# Altium schematic sheet FPGA.SchDoc(FPGA)
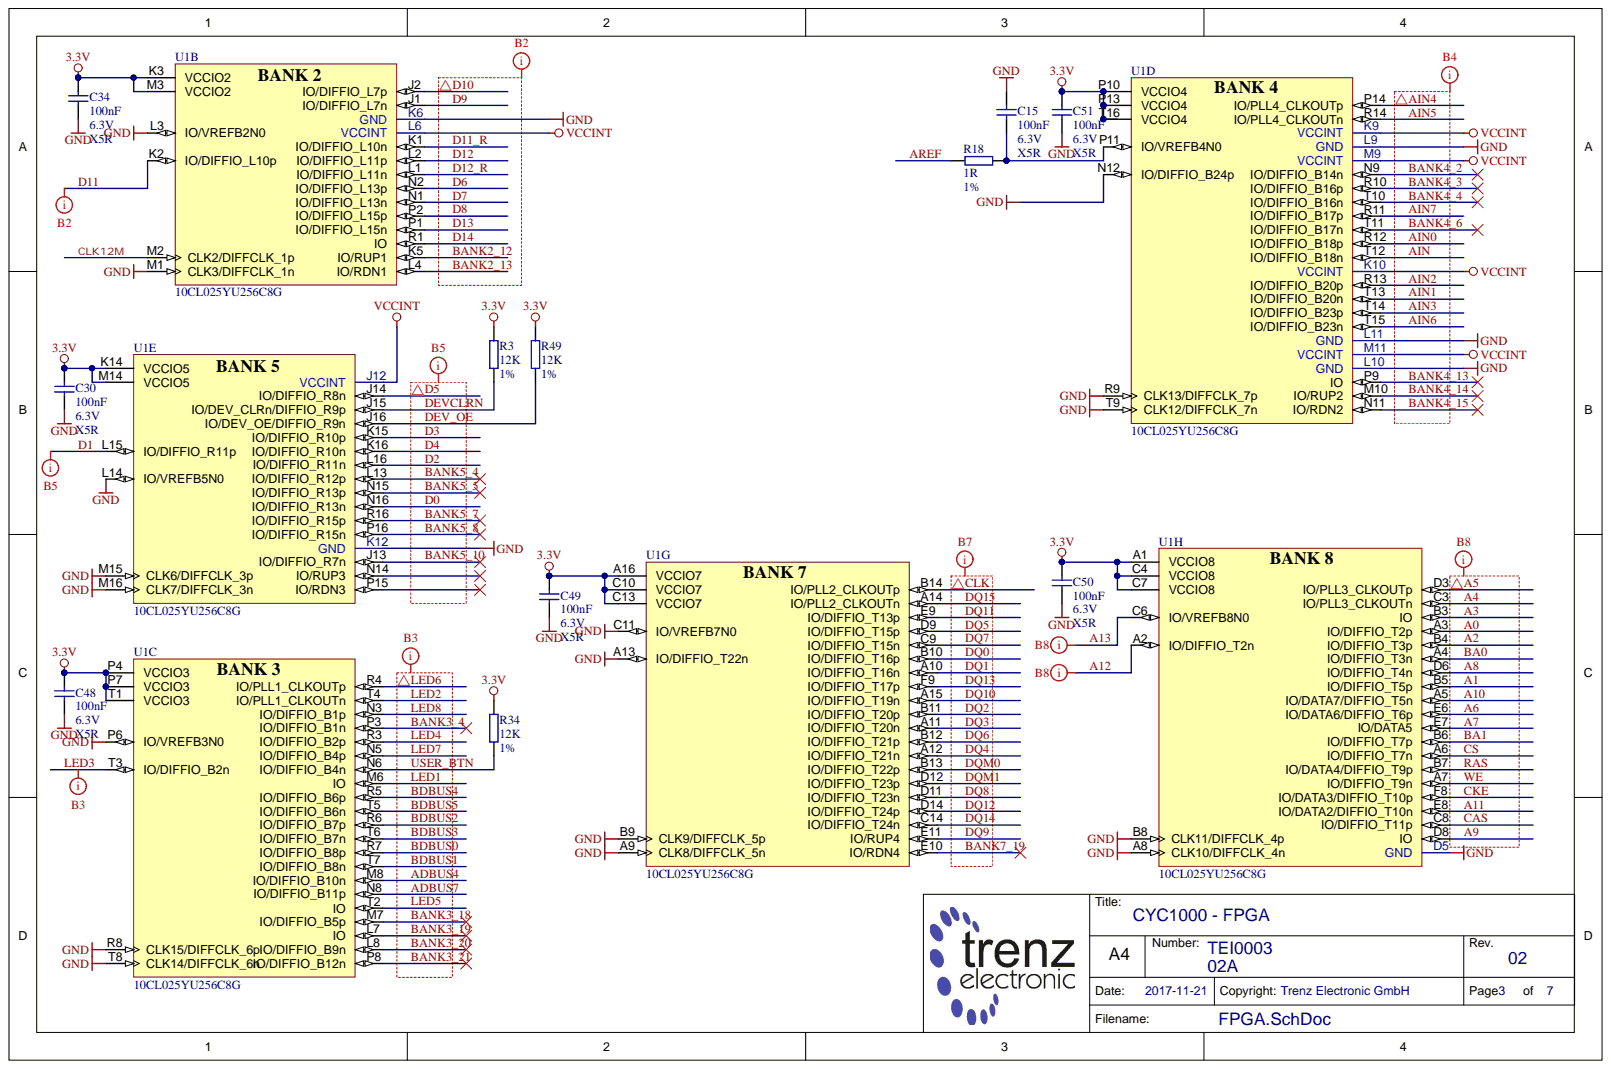
[diagram p0: FPGA.SchDoc]

## Parts
C15  100nF  Voltage: 6.3V  [CAPC0603X33N]
C30  100nF  Voltage: 6.3V  [CAPC0603X33N]
C34  100nF  Technology: X5R  Voltage: 6.3V  [CAPC0603X33N]
C48  100nF  Voltage: 6.3V  [CAPC0603X33N]
C49  100nF  Technology: X5R  Voltage: 6.3V  [CAPC0603X33N]
C50  100nF  Technology: X5R  Voltage: 6.3V  [CAPC0603X33N]
C51  100nF  Voltage: 6.3V  [CAPC0603X33N]
R3  12K  Tolerance: 1%  [RESC1005X40N]
R18  1R  Tolerance: 1%  [RESC1005X40N]
R34  12K  Tolerance: 1%  [RESC1005X40N]
R49  12K  Tolerance: 1%  [RESC1005X40N]
U1  10CL025YU256C8G  [BGA256C80_1400X1400X150B50]  (parts B,C,D,E,G,H)

## Nets
3.3V: C30.1 C34.1 C48.1 C49.1 C50.1 C51.1 R3.2 R34.2 R49.2 U1.A1(VCCIO8) U1.A16(VCCIO7) U1.C4(VCCIO8) U1.C7(VCCIO8) U1.C10(VCCIO7) U1.C13(VCCIO7) U1.K3(VCCIO2) U1.K14(VCCIO5) U1.M3(VCCIO2) U1.M14(VCCIO5) U1.P4(VCCIO3) U1.P7(VCCIO3) U1.P10(VCCIO4) U1.P13(VCCIO4) U1.T1(VCCIO3) U1.T16(VCCIO4)
GND: C15.2 C30.2 C34.2 C48.2 C49.2 C50.2 C51.2 U1.A8(CLK10/DIFFCLK_4n) U1.A9(CLK8/DIFFCLK_5n) U1.A13(IO/DIFFIO_T22n) U1.B8(CLK11/DIFFCLK_4p) U1.B9(CLK9/DIFFCLK_5p) U1.C11(IO/VREFB7N0) U1.D5(GND) U1.K6(GND) U1.K12(GND) U1.L3(IO/VREFB2N0) U1.L9(GND) U1.L10(GND) U1.L11(GND) U1.L14(IO/VREFB5N0) U1.M1(CLK3/DIFFCLK_1n) U1.M15(CLK6/DIFFCLK_3p) U1.M16(CLK7/DIFFCLK_3n) U1.N12(IO/DIFFIO_B24p) U1.P6(IO/VREFB3N0) U1.R8(CLK15/DIFFCLK_6p) U1.R9(CLK13/DIFFCLK_7p) U1.T8(CLK14/DIFFCLK_6n) U1.T9(CLK12/DIFFCLK_7n)
VCCINT: U1.J12(VCCINT) U1.K9(VCCINT) U1.K10(VCCINT) U1.L6(VCCINT) U1.M9(VCCINT) U1.M11(VCCINT)
A0: U1.A3(IO/DIFFIO_T2p)
A1: U1.B5(IO/DIFFIO_T5p)
A2: U1.B4(IO/DIFFIO_T3p)
A3: U1.B3(IO)
A4: U1.C3(IO/PLL3_CLKOUTn)
A5: U1.D3(IO/PLL3_CLKOUTp)
A6: U1.E6(IO/DATA6/DIFFIO_T6p)
A7: U1.E7(IO/DATA5)
A8: U1.D6(IO/DIFFIO_T4n)
A9: U1.D8(IO)
A10: U1.A5(IO/DATA7/DIFFIO_T5n)
A11: U1.E8(IO/DATA2/DIFFIO_T10n)
A12: U1.A2(IO/DIFFIO_T2n)
A13: U1.C6(IO/VREFB8N0)
ADBUS4: U1.M8(IO/DIFFIO_B10n)
ADBUS7: U1.N8(IO/DIFFIO_B11p)
AIN: U1.T12(IO/DIFFIO_B18n)
AIN0: U1.R12(IO/DIFFIO_B18p)
AIN1: U1.T13(IO/DIFFIO_B20n)
AIN2: U1.R13(IO/DIFFIO_B20p)
AIN3: U1.T14(IO/DIFFIO_B23p)
AIN4: U1.P14(IO/PLL4_CLKOUTp)
AIN5: U1.R14(IO/PLL4_CLKOUTn)
AIN6: U1.T15(IO/DIFFIO_B23n)
AIN7: U1.R11(IO/DIFFIO_B17p)
AREF: R18.1
BA0: U1.A4(IO/DIFFIO_T3n)
BA1: U1.B6(IO/DIFFIO_T7p)
BANK2_12: U1.K5(IO/RUP1)
BANK2_13: U1.L4(IO/RDN1)
BANK3_4: U1.P3(IO/DIFFIO_B1n)
BANK3_18: U1.M7(IO/DIFFIO_B5p)
BANK3_19: U1.L7(IO)
BANK3_20: U1.L8(IO/DIFFIO_B9n)
BANK3_21: U1.P8(IO/DIFFIO_B12n)
BANK4_2: U1.N9(IO/DIFFIO_B14n)
BANK4_3: U1.R10(IO/DIFFIO_B16p)
BANK4_4: U1.T10(IO/DIFFIO_B16n)
BANK4_6: U1.T11(IO/DIFFIO_B17n)
BANK4_13: U1.P9(IO)
BANK4_14: U1.M10(IO/RUP2)
BANK4_15: U1.N11(IO/RDN2)
BANK5_4: U1.L13(IO/DIFFIO_R12p)
BANK5_5: U1.N15(IO/DIFFIO_R13p)
BANK5_7: U1.R16(IO/DIFFIO_R15p)
BANK5_8: U1.P16(IO/DIFFIO_R15n)
BANK5_10: U1.J13(IO/DIFFIO_R7n)
BANK7_19: U1.E10(IO/RDN4)
BDBUS0: U1.R7(IO/DIFFIO_B8p)
BDBUS1: U1.T7(IO/DIFFIO_B8n)
BDBUS2: U1.R6(IO/DIFFIO_B7p)
BDBUS3: U1.T6(IO/DIFFIO_B7n)
BDBUS4: U1.R5(IO/DIFFIO_B6p)
BDBUS5: U1.T5(IO/DIFFIO_B6n)
CAS: U1.C8(IO/DIFFIO_T11p)
CKE: U1.F8(IO/DATA3/DIFFIO_T10p)
CLK: U1.B14(IO/PLL2_CLKOUTp)
CLK12M: U1.M2(CLK2/DIFFCLK_1p)
CS: U1.A6(IO/DIFFIO_T7n)
D0: U1.N16(IO/DIFFIO_R13n)
D1: U1.L15(IO/DIFFIO_R11p)
D2: U1.L16(IO/DIFFIO_R11n)
D3: U1.K15(IO/DIFFIO_R10p)
D4: U1.K16(IO/DIFFIO_R10n)
D5: U1.J14(IO/DIFFIO_R8n)
D6: U1.N2(IO/DIFFIO_L13p)
D7: U1.N1(IO/DIFFIO_L13n)
D8: U1.P2(IO/DIFFIO_L15p)
D9: U1.J1(IO/DIFFIO_L7n)
D10: U1.J2(IO/DIFFIO_L7p)
D11: U1.K2(IO/DIFFIO_L10p)
D11_R: U1.K1(IO/DIFFIO_L10n)
D12: U1.L2(IO/DIFFIO_L11p)
D12_R: U1.L1(IO/DIFFIO_L11n)
D13: U1.P1(IO/DIFFIO_L15n)
D14: U1.R1(IO)
DEV_OE: R49.1 U1.J16(IO/DEV_OE/DIFFIO_R9n)
DEVCLRN: R3.1 U1.J15(IO/DEV_CLRn/DIFFIO_R9p)
DQ0: U1.B10(IO/DIFFIO_T16p)
DQ1: U1.A10(IO/DIFFIO_T16n)
DQ2: U1.B11(IO/DIFFIO_T20p)
DQ3: U1.A11(IO/DIFFIO_T20n)
DQ4: U1.A12(IO/DIFFIO_T21n)
DQ5: U1.D9(IO/DIFFIO_T15p)
DQ6: U1.B12(IO/DIFFIO_T21p)
DQ7: U1.C9(IO/DIFFIO_T15n)
DQ8: U1.D11(IO/DIFFIO_T23n)
DQ9: U1.E11(IO/RUP4)
DQ10: U1.A15(IO/DIFFIO_T19n)
DQ11: U1.E9(IO/DIFFIO_T13p)
DQ12: U1.D14(IO/DIFFIO_T24p)
DQ13: U1.F9(IO/DIFFIO_T17p)
DQ14: U1.C14(IO/DIFFIO_T24n)
DQ15: U1.A14(IO/PLL2_CLKOUTn)
DQM0: U1.B13(IO/DIFFIO_T22p)
DQM1: U1.D12(IO/DIFFIO_T23p)
LED1: U1.M6(IO)
LED2: U1.T4(IO/PLL1_CLKOUTn)
LED3: U1.T3(IO/DIFFIO_B2n)
LED4: U1.R3(IO/DIFFIO_B2p)
LED5: U1.T2(IO)
LED6: U1.R4(IO/PLL1_CLKOUTp)
LED7: U1.N5(IO/DIFFIO_B4p)
LED8: U1.N3(IO/DIFFIO_B1p)
RAS: U1.B7(IO/DATA4/DIFFIO_T9p)
USER_BTN: R34.1 U1.N6(IO/DIFFIO_B4n)
WE: U1.A7(IO/DIFFIO_T9n)
NetC15_1: C15.1 R18.2 U1.P11(IO/VREFB4N0)
N00177:
N00178:
N00179:
N00180:
N00181:
N00182:

Not connected: U1 N14(IO/RUP3) P15(IO/RDN3)

## Library data of the parts
C15 C30 C34 C48-C51 = 24915: KON 100nF 6.3V X5R SMD 10%; Database Table Name: C_0603_0201; Mixed: SMT; Preferred: Yes; Value: 100n; AN: 24915; Case: 0603 (0201); Component Kind: Standard; Library Name: TE.DbLib; Tolerance: 10%
  C15 C30 C48 C51: Technology: X5R
R3 R34 R49 = 22598: WID SMD 12K 1% 0,063W; Database Table Name: R_1005_0402; Mixed: SMT; Preferred: Yes; AN: 22598; Case: 1005 (0402); Component Kind: Standard; Library Name: TE.DbLib; Technology: TK100; Value: 12K
R18 = 27510: WID SMD 1R 1% 0,063W; Database Table Name: R_1005_0402; Mixed: SMT; Value: 1; AN: 27510; Case: 1005 (0402); Component Kind: Standard; Library Name: TE.DbLib; Technology: TK100
U1 = 28294: Database Table Name: PROG_LOGIC; Mixed: SMT; AN: 28294; Case: UBGA256; Component Kind: Standard; Description: 10CL025YU256C8G; H-ART: 10CL025YU256C8G; Library Name: TE.DbLib; HelpURL: B:\ad\adlib\datasheet\Altera_Cyclone
